# Altium schematic sheet eva_pn532_v1.1.schdoc
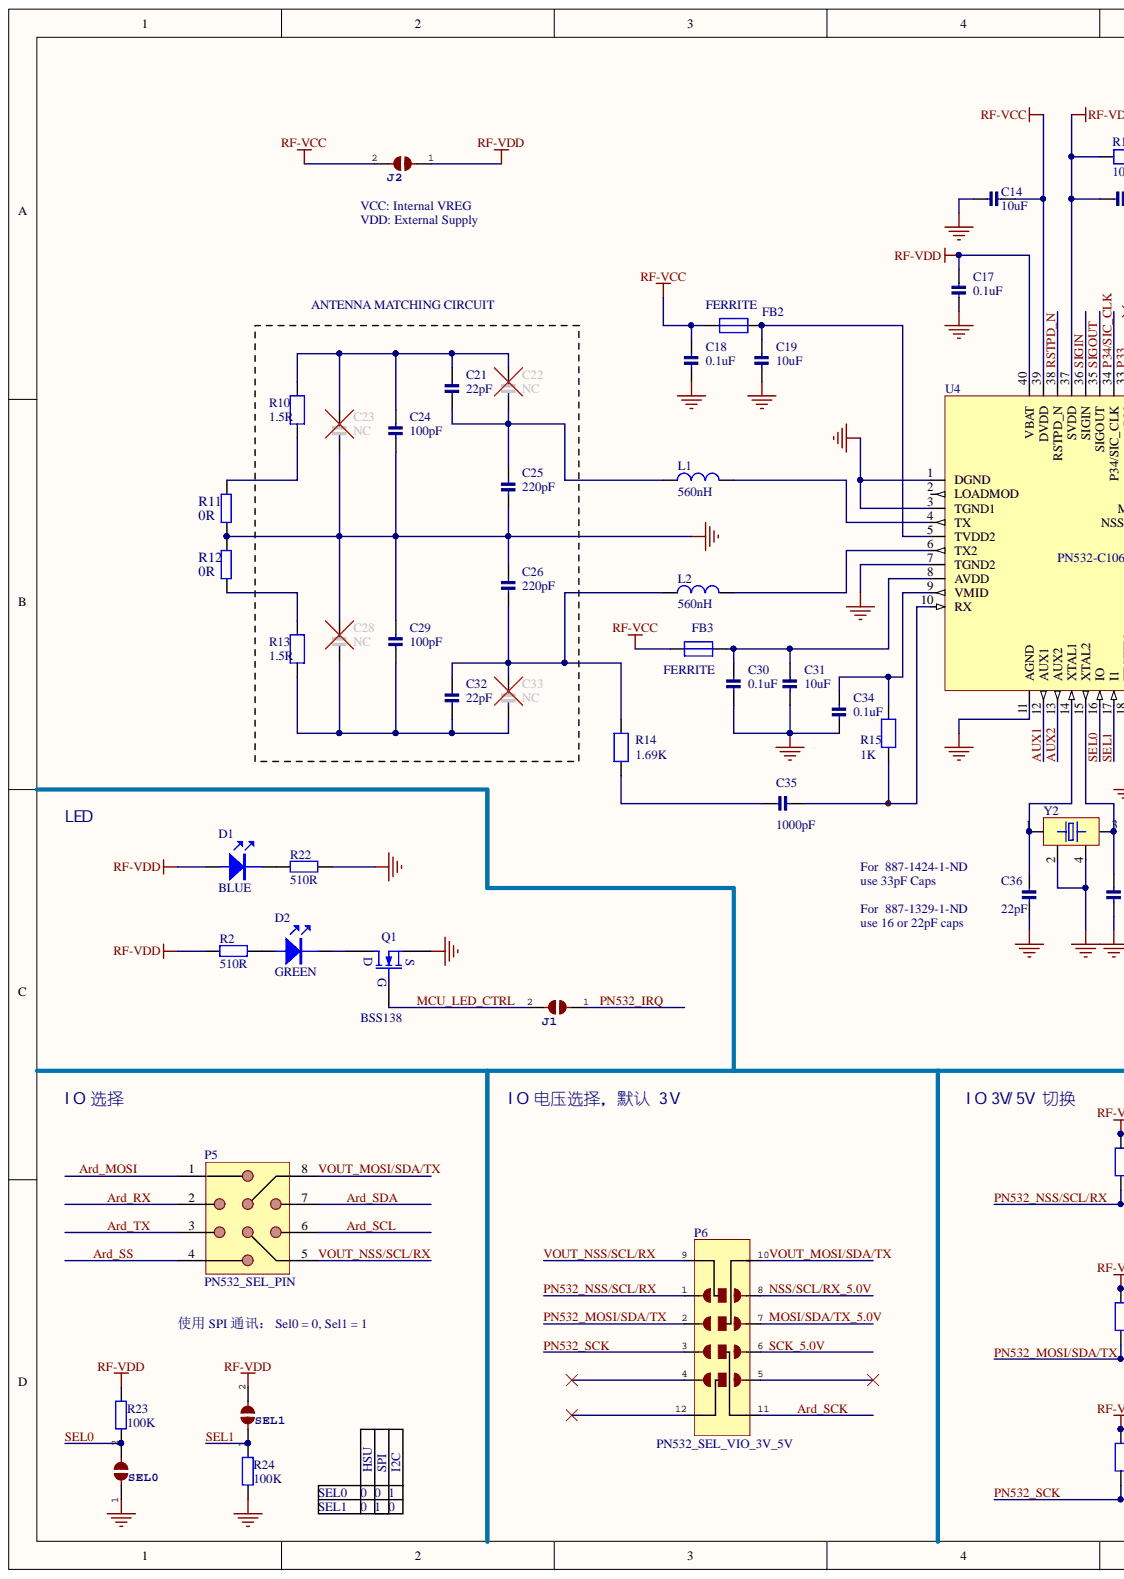
[diagram p0: eva_pn532_v1.1.schdoc, left]
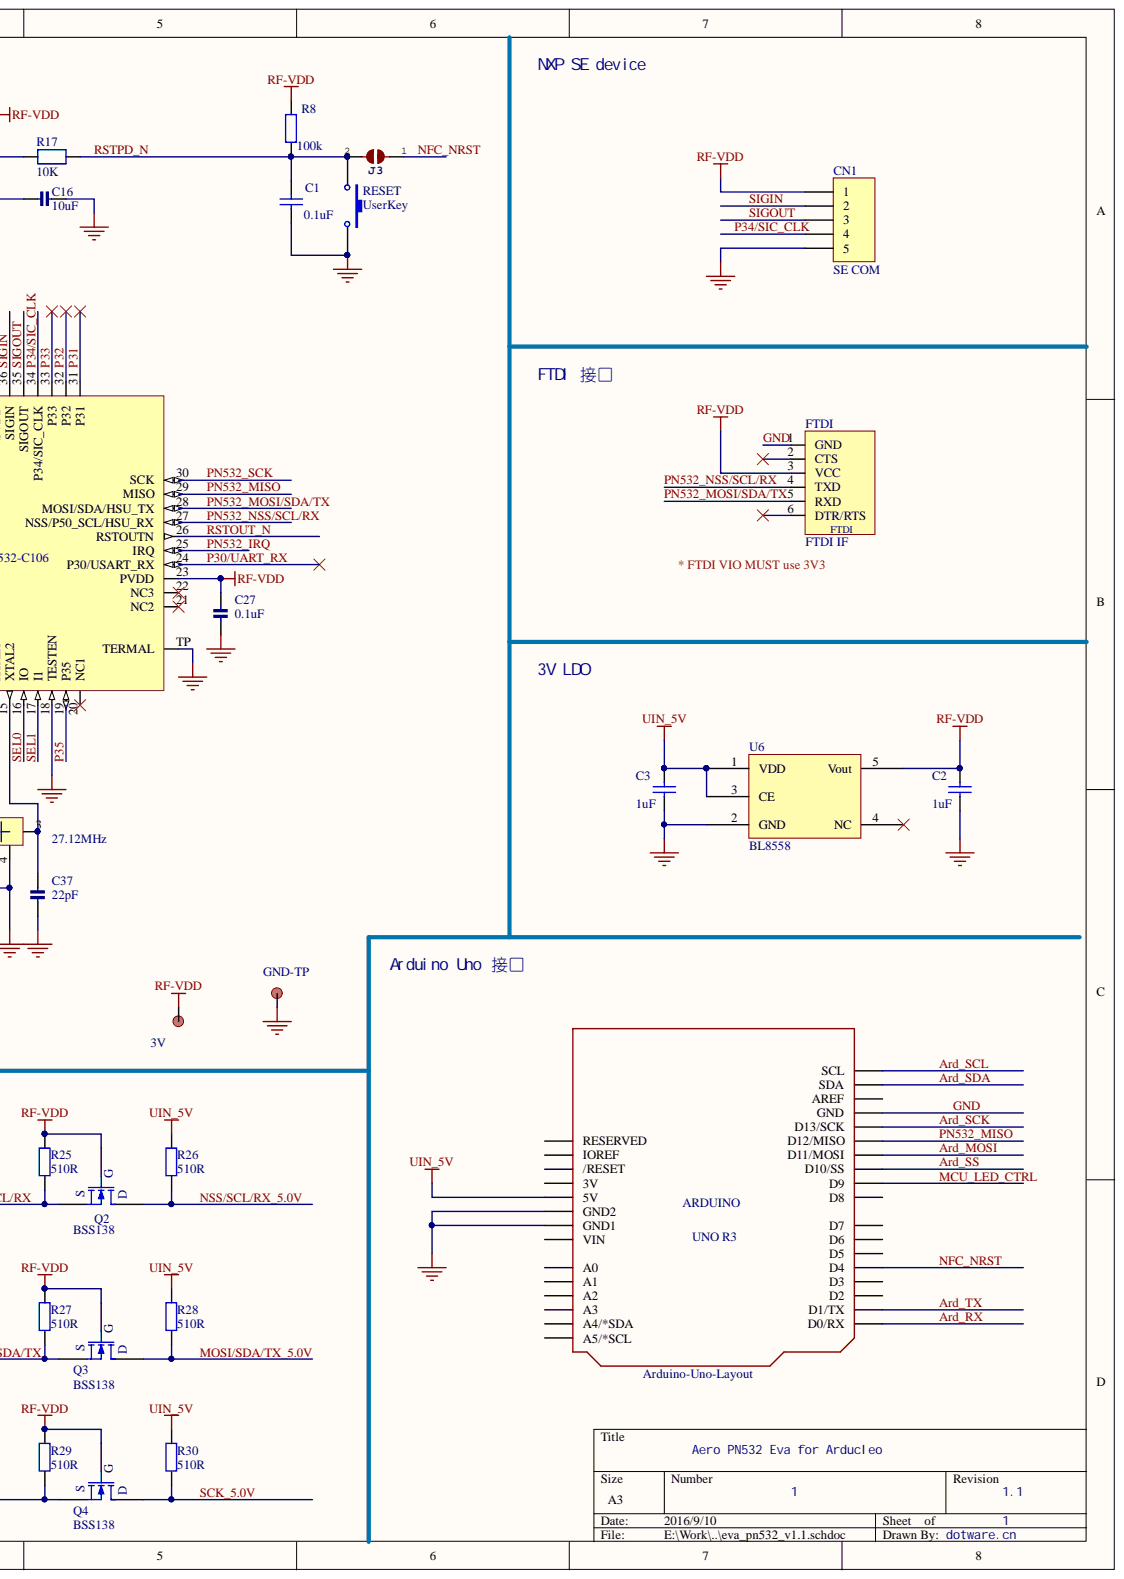
[diagram p1: eva_pn532_v1.1.schdoc, right]

## Parts
ARDUINO1  Arduino-Uno-Layout  [ARDUINOR3-NODIM]
C1  0.1uF  [0603_1608-CAP]
C2  1uF  [0603_1608-CAP]
C3  1uF  [0603_1608-CAP]
C14  10uF  [0805_2012-CAP]
C16  10uF  [0805_2012-CAP]
C17  0.1uF  [0603_1608-CAP]
C18  0.1uF  [0603_1608-CAP]
C19  10uF  [0805_2012-CAP]
C21  22pF  [0603_1608-CAP]
C22  NC  [0603_1608-CAP]
C23  NC  [0603_1608-CAP]
C24  100pF  [0603_1608-CAP]
C25  220pF  [0603_1608-CAP]
C26  220pF  [0603_1608-CAP]
C27  0.1uF  [0603_1608-CAP]
C28  NC  [0603_1608-CAP]
C29  100pF  [0603_1608-CAP]
C30  0.1uF  [0603_1608-CAP]
C31  10uF  [0805_2012-CAP]
C32  22pF  [0603_1608-CAP]
C33  NC  [0603_1608-CAP]
C34  0.1uF  [0603_1608-CAP]
C35  1000pF  [0603_1608-CAP]
C36  22pF  [0603_1608-CAP]
C37  22pF  [0603_1608-CAP]
CN1  SE COM  [1*5P_FEMALE]
D1  BLUE  [LED-0603-B]
D2  GREEN  [LED-0603-G]
FB2  FERRITE  [L603]
FB3  FERRITE  [L603]
FTDI  FTDI IF  [1*6P_FEMALE]
G  GND-TP  [TP-C]
J1  J1  [SJ_2-NO]
J2  J2  [SJ_2-NO]
J3  J3  [SJ_2-NO]
L1  560nH  [L805]
L2  560nH  [L805]
P5  PN532_SEL_PIN  [PN532_SEL_PIN]
P6  PN532_SEL_VIO_3V_5V  [PN532_SEL_VIO_3V_5V]
Q1  BSS138  [SOT-23-3]
Q2  BSS138  [SOT-23-3]
Q3  BSS138  [SOT-23-3]
Q4  BSS138  [SOT-23-3]
R2  510R  [0603_1608-Res]
R8  100k  [0603_1608-Res]
R10  1.5R  [0603_1608-Res]
R11  0R  [PN532_ANT_CONN]
R12  0R  [PN532_ANT_CONN]
R13  1.5R  [0603_1608-Res]
R14  1.69K  [0603_1608-Res]
R15  1K  [0603_1608-Res]
R17  10K  [0603_1608-Res]
R22  510R  [0603_1608-Res]
R23  100K  [0603_1608-Res]
R24  100K  [0603_1608-Res]
R25  510R  [0603_1608-Res]
R26  510R  [0603_1608-Res]
R27  510R  [0603_1608-Res]
R28  510R  [0603_1608-Res]
R29  510R  [0603_1608-Res]
R30  510R  [0603_1608-Res]
RESET  UserKey  [TACTILE-SWITCH-SMD-ALT01]
SEL0  SEL0  [1X02]
SEL1  SEL1  [1X02]
TP-3V3  3V  [TP-C]
U4  PN532-C106  [HVQFN40-6X6-V2]
U6  BL8558  [SOT-23-5X]
Y2  27.12MHz  [CRY_3.2X2.5small]

## Nets
RF-VCC: C14.1 C18.1 FB2.1 FB3.2 J2.2 U4.39(DVDD)
RF-VDD: C2.1 C16.2 C17.1 C27.1 CN1.1 D1.A FTDI.3(VCC) J2.1 Q2.1 Q3.1 Q4.1 R2.1 R8.2 R17.2 R23.2 R25.1 R27.1 R29.1 SEL1.2 TP-3V3.1 U4.23(PVDD) U4.37(SVDD) U4.40(VBAT) U6.5(Vout)
UIN_5V: ARDUINO1.5V C3.1 R26.1 R28.1 R30.1 U6.1(VDD) U6.3(CE)
ARD_MOSI: ARDUINO1.D11(D11/MOSI) P5.1
ARD_RX: ARDUINO1.D0(D0/RX) P5.2
ARD_SCK: ARDUINO1.D13(D13/SCK) P6.11
ARD_SCL: ARDUINO1.SCL P5.6
ARD_SDA: ARDUINO1.SDA P5.7
ARD_SS: ARDUINO1.D10(D10/SS) P5.4
ARD_TX: ARDUINO1.D1(D1/TX) P5.3
AUX1: U4.12(AUX1)
AUX2: U4.13(AUX2)
GND: ARDUINO1.GND ARDUINO1.GND1 ARDUINO1.GND2 C1.2 C2.2 C3.2 C14.2 C16.1 C17.2 C18.2 C19.2 C23.2 C24.2 C25.2 C26.1 C27.2 C28.1 C29.1 C30.2 C31.2 C34.2 C36.2 C37.2 CN1.5 FTDI.1(GND) G.1 Q1.2 R11.1 R12.2 R22.1 R24.1 RESET.2 SEL0.1 U4.1(DGND) U4.3(TGND1) U4.7(TGND2) U4.11(AGND) U4.18(TESTEN) U4.TP(TERMAL) U6.2(GND) Y2.2 Y2.4
MCU_LED_CTRL: ARDUINO1.D9 J1.2 Q1.1
MOSI/SDA/TX_5.0V: P6.7 Q3.3 R28.2
NFC_NRST: ARDUINO1.D4 J3.1
NSS/SCL/RX_5.0V: P6.8 Q2.3 R26.2
P30/UART_RX: U4.24(P30/USART_RX)
P31: U4.31(P31)
P32: U4.32(P32)
P33: U4.33(P33)
P34/SIC_CLK: CN1.4 U4.34(P34/SIC_CLK)
P35: U4.19(P35)
PN532_IRQ: J1.1 U4.25(IRQ)
PN532_MISO: ARDUINO1.D12(D12/MISO) U4.29(MISO)
PN532_MOSI/SDA/TX: FTDI.5(RXD) P6.2 Q3.2 R27.2 U4.28(MOSI/SDA/HSU_TX)
PN532_NSS/SCL/RX: FTDI.4(TXD) P6.1 Q2.2 R25.2 U4.27(NSS/P50_SCL/HSU_RX)
PN532_SCK: P6.3 Q4.2 R29.2 U4.30(SCK)
RSTOUT_N: U4.26(RSTOUTN)
RSTPD_N: C1.1 J3.2 R8.1 R17.1 RESET.1 U4.38(RSTPD_N)
SCK_5.0V: P6.6 Q4.3 R30.2
SEL0: R23.1 SEL0.2 U4.16(IO)
SEL1: R24.2 SEL1.1 U4.17(I1)
SIGIN: CN1.2 U4.36(SIGIN)
SIGOUT: CN1.3 U4.35(SIGOUT)
VOUT_MOSI/SDA/TX: P5.8 P6.10
VOUT_NSS/SCL/RX: P5.5 P6.9
NetC19_1: C19.1 FB2.2 U4.5(TVDD2)
NetC21_1: C21.1 C22.1 C23.1 C24.1 R10.2
NetC21_2: C21.2 C22.2 C25.1 L1.1
NetC26_2: C26.2 C32.1 C33.1 L2.1 R14.2
NetC28_2: C28.2 C29.2 C32.2 C33.2 R13.1
NetC30_1: C30.1 C31.1 FB3.1 U4.8(AVDD)
NetC34_1: C34.1 R15.2 U4.9(VMID)
NetC35_1: C35.1 R14.1
NetC35_2: C35.2 R15.1 U4.10(RX)
NetC36_1: C36.1 U4.14(XTAL1) Y2.1
NetC37_1: C37.1 U4.15(XTAL2) Y2.3
NetD1_K: D1.K R22.2
NetD2_A: D2.A R2.2
NetD2_K: D2.K Q1.3
NetL1_2: L1.2 U4.4(TX)
NetL2_2: L2.2 U4.6(TX2)
NetR10_1: R10.1 R11.2
NetR12_1: R12.1 R13.2

Not connected: ARDUINO1 3V A0 A1 A2 A3 A4(A4/*SDA) A5(A5/*SCL) AREF D2 D3 D5 D6 D7 D8 IOREF RESERVED RESET(/RESET) VIN; FTDI 2(CTS) 6(DTR/RTS); P6 4 5 12; U4 2(LOADMOD) 20(NC1) 21(NC2) 22(NC3); U6 4(NC)

## Library data of the parts
ARDUINO1 = ARDUINO-UnoR3: Component Kind: Standard; Library Name: Arduinox.SchLib
C1 C3 = GRM188R71C10: CAP CER .1UF 16V 10% X7R 0603; Manufacturer: Murata Electronics North America; Manufacturer Part Number: GRM188R71C104KA01D; Database Table Name: 电容器; Component Kind: Standard; Library Name: Altium_SVN_Library.SVNDbLib; Part Number: GRM188R71C104KA01D; Supplier 1: Digi-Key; Supplier Part Number 1: 490-1532-2-ND
C2 = GRM188R71C104KA0: CAP CER .1UF 16V 10% X7R 0603; Manufacturer: Murata Electronics North America; Manufacturer Part Number: GRM188R71C104KA01D; Database Table Name: 电容器; Component Kind: Standard; Library Name: Altium_SVN_Library.SVNDbLib; Part Number: GRM188R71C104KA01D; Supplier 1: Digi-Key; Supplier Part Number 1: 490-1532-2-ND
C14 C16-C19 C21-C37 = CAP_CERAMIC0805: Component Kind: Standard; Library Name: microbuilder
CN1 = Header 5: Header, 5-Pin; Component Kind: Standard; LatestRevisionDate: 17-Jul-2002; LatestRevisionNote: Re-released for DXP Platform.; Library Name: Miscellaneous Connectors.IntLib; Publisher: Altium Limited
D1 = LED: POWER LED; Component Kind: Standard; Library Name: SCH-090727.Lib
D2 = LED: LED IRQ INDICATOR; Component Kind: Standard; Library Name: SCH-090727.Lib
FTDI = FTDI_DEV_IF: FTDI Sparkfun PIN; Component Kind: Standard; Library Name: Arduinox.SchLib
G TP-3V3 = PAD_TP: Component Kind: Standard; Library Name: Arduinox.SchLib
J1-J3 SEL0 SEL1 = Solder Jumper: SMD Solder JUMPER   Solder the two pads together to create a connection, or remove the solder to break it.REFLOW - Use this footprint for solder paste and reflow ovens. WAVE - Use this footprint for hand-soldering (larger pads).    CLOSED - Has a trace between the two pads to ensure it is closed by default.  The trace needs to be cut to disable the jumper, and can be closed again by creating a solder bridge between the two pads.; Component Kind: Standard; Library Name: Arduinox.SchLib
L1 L2 = INDUCTOR: Component Kind: Standard; Library Name: SCH-090727.Lib
Q1-Q4 = A3400A-NMOS: Component Kind: Standard; Library Name: Arduino.SchLib
R2 R8 = RC: RES 1.0M OHM 1/10W 5% 0603 SMD; Manufacturer: Yageo; Manufacturer Part Number: RC0603JR-071ML; Database Table Name: 电阻器、电位计; Component Kind: Standard; Library Name: Altium_SVN_Library.SVNDbLib; Part Number: RC0603JR-071ML; Supplier 1: Digi-Key; Supplier Part Number 1: 311-1.0MGRDKR-ND
R10 R13-R15 R17 = RESISTOR0805: Component Kind: Standard; Library Name: microbuilder
R11 R12 = R-EU_R0201: Component Kind: Net Tie; Library Name: rcl
R22 R25-R30 = RC0603JR: RES 1.0K OHM 1/10W 5% 0603 SMD; Manufacturer: Yageo; Manufacturer Part Number: RC0603JR-071KL; Database Table Name: 电阻器、电位计; Component Kind: Standard; Library Name: Altium_SVN_Library_DB.DbLib; Part Number: RC0603JR-071KL; Supplier 1: Digi-Key; Supplier Part Number 1: 311-1.0KGRCT-ND
R23 R24 = (no symbol name): RES 1.0M OHM 1/10W 5% 0603 SMD; Manufacturer: Yageo; Manufacturer Part Number: RC0603JR-071ML; Component Kind: Standard; Part Number: RC0603JR-071ML; Supplier 1: Digi-Key; Supplier Part Number 1: 311-1.0MGRDKR-ND; Library Name: Pottery2012.SCHLIB
RESET = SW-PB: Switch; Component Kind: Standard; LatestRevisionDate: 17-Jul-2002; LatestRevisionNote: Re-released for DXP Platform.; Library Name: Miscellaneous Devices.IntLib; PackageReference: SPST-2; Published: 24-Mar-1999; Publisher: Altium Limited
U6 = BL8555-33: Low noise, High PSRR; Manufacturer: 上海贝岭; Manufacturer Part Number: BL8555-33PRA; Database Table Name: 集成电路 (IC); Component Kind: Standard; Library Name: Altium_SVN_Library.SVNDbLib; Part Number: BL8555-33PRA
Y2 = CRY: Component Kind: Standard; Library Name: Pottery2012.SCHLIB
FB2 FB3 = FERRITE: Component Kind: Standard; Library Name: microbuilder
P5 = PN532_SEL_PIN: Component Kind: Standard; Library Name: Arduinox.SchLib
P6 = PN532_SEL_VIO_3V_5V: Component Kind: Standard; Library Name: Arduinox.SchLib
U4 = PN532-C106: Component Kind: Standard; Library Name: nxp_reader_ic.SchLib
